# Altium schematic sheet CUBETTO-IO.SchDoc(CUBETTO-IO)
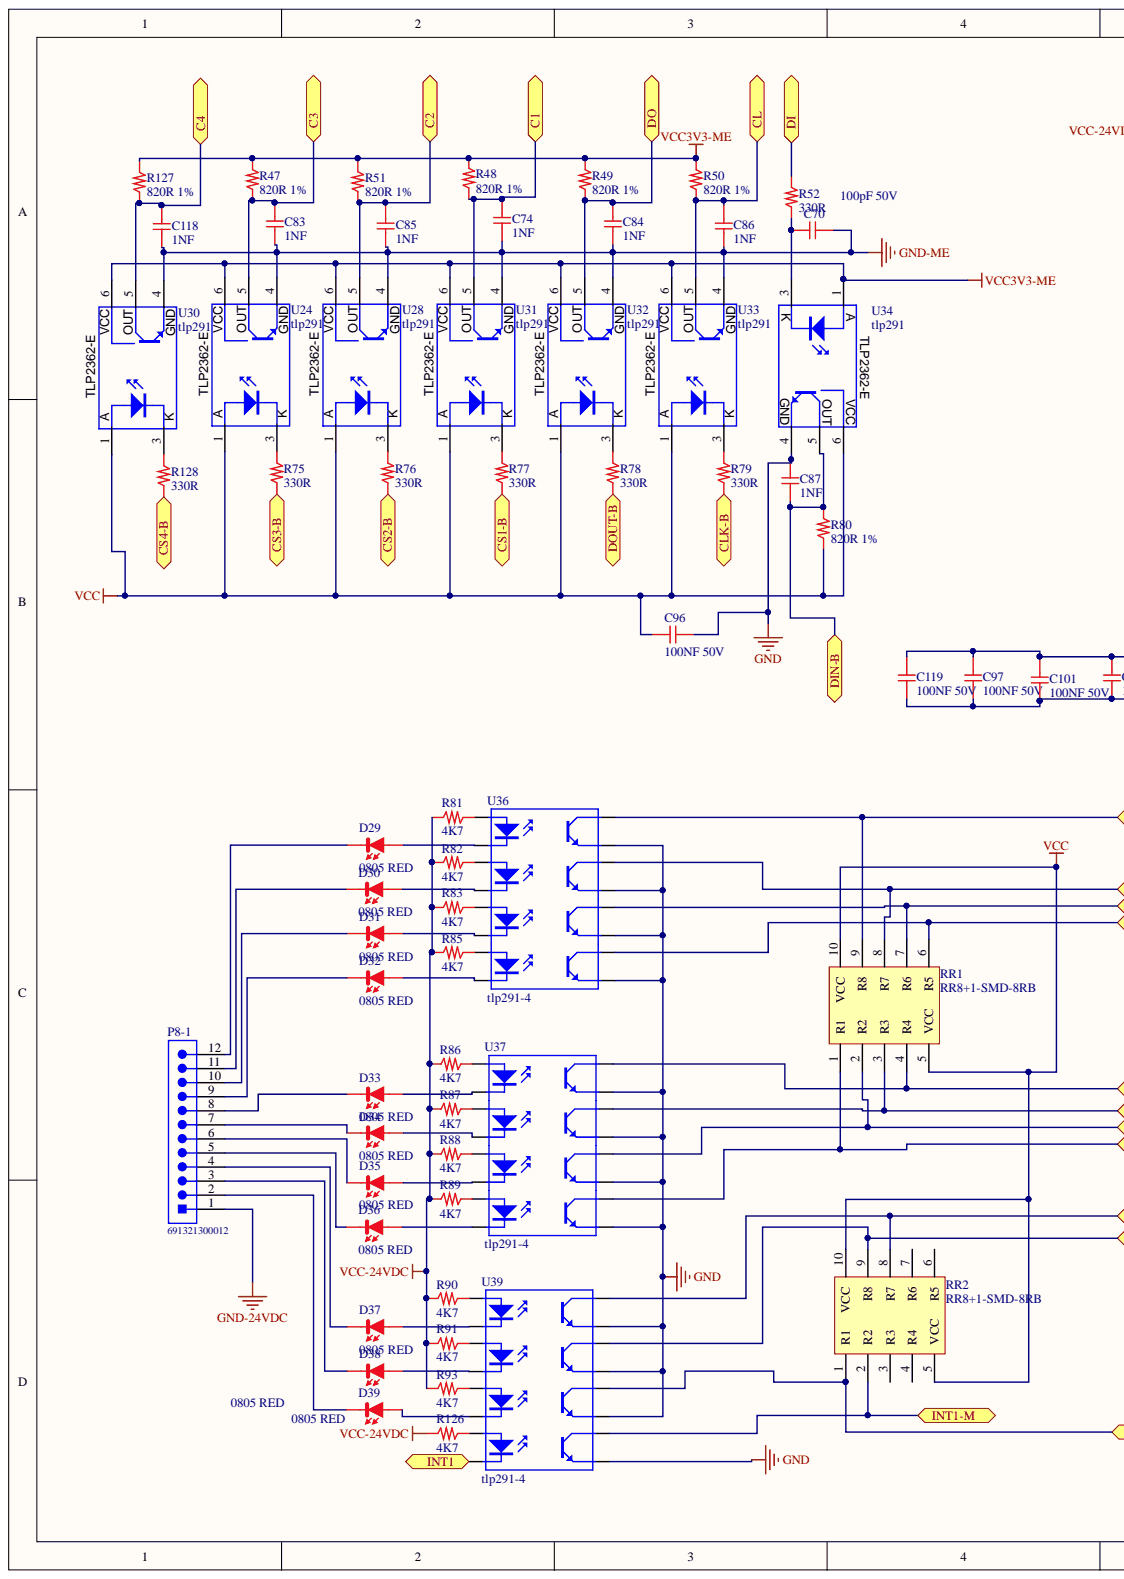
[diagram p0: CUBETTO-IO.SchDoc, left]
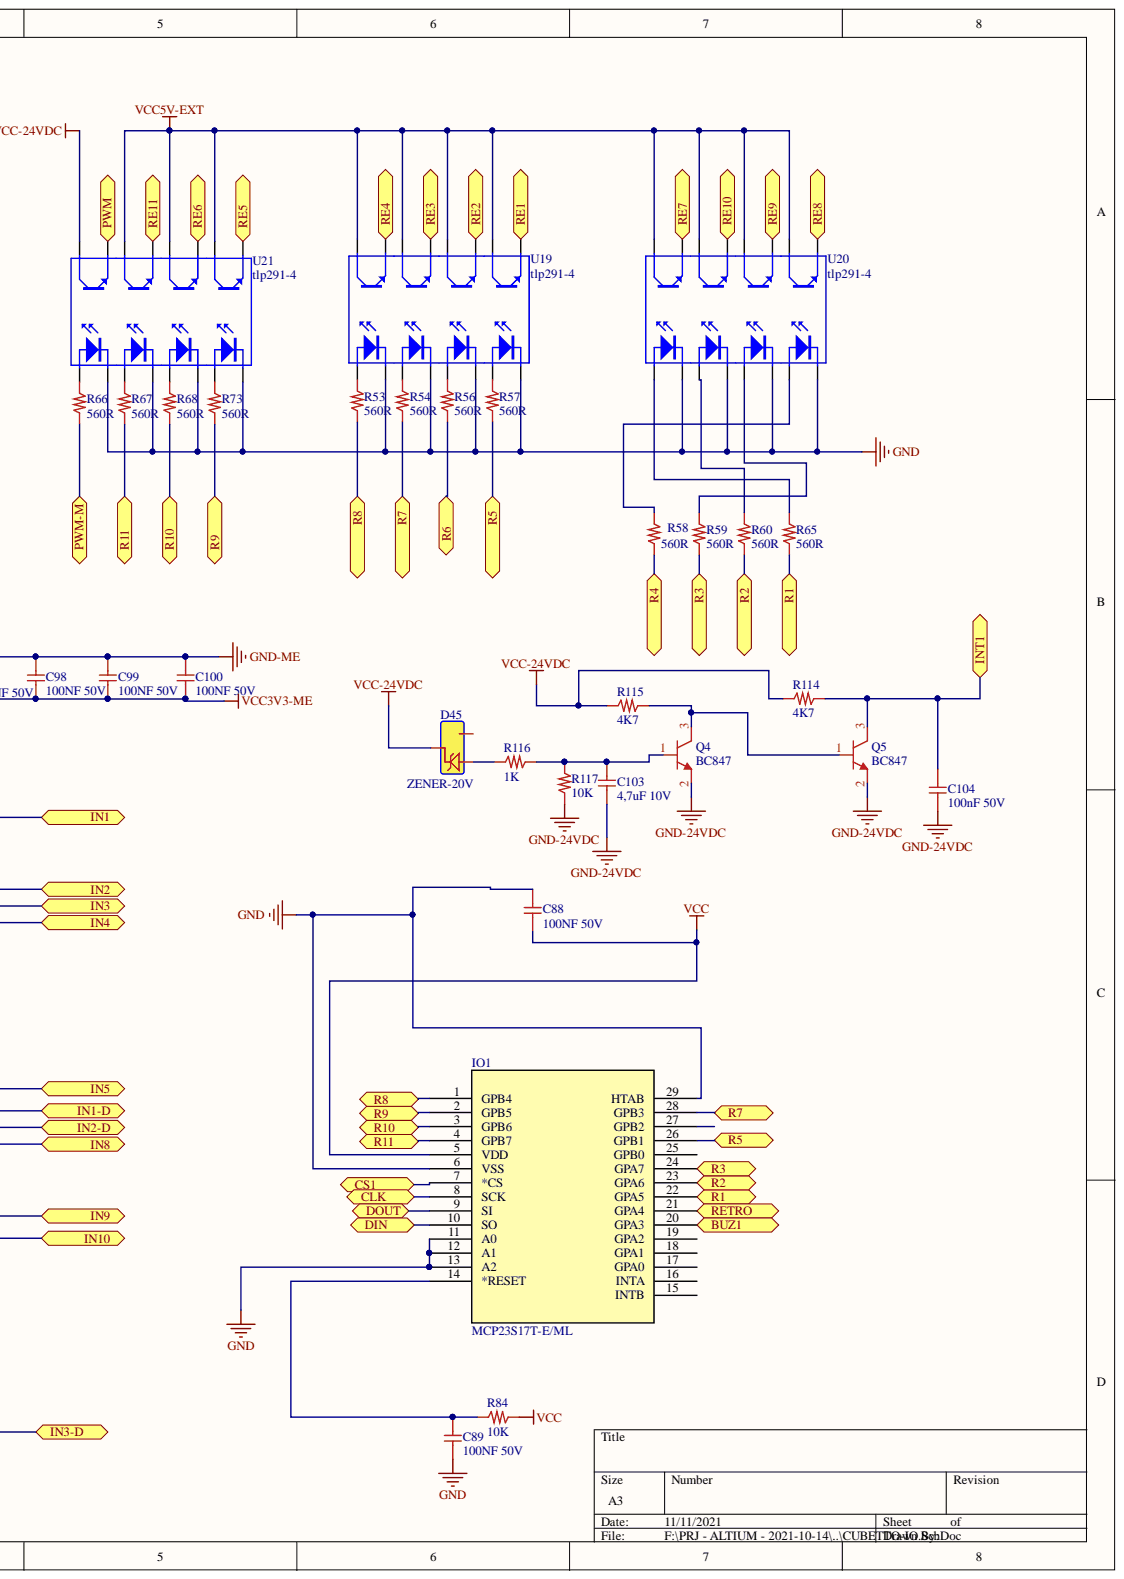
[diagram p1: CUBETTO-IO.SchDoc, right]

## Parts
C70  100pF 50V  [0402_C]
C74  1NF  [0402_C]
C83  1NF  [0402_C]
C84  1NF  [0402_C]
C85  1NF  [0402_C]
C86  1NF  [0402_C]
C87  1NF  [0402_C]
C88  100NF 50V  [0603_c]
C89  100NF 50V  [0603_c]
C96  100NF 50V  [0603_c]
C97  100NF 50V  [0603_c]
C98  100NF 50V  [0603_c]
C99  100NF 50V  [0603_c]
C100  100NF 50V  [0603_c]
C101  100NF 50V  [0603_c]
C103  4,7uF 10V  [0603_c]
C104  100nF 50V  [0603_c]
C118  1NF  [0402_C]
C119  100NF 50V  [0603_c]
D29  0805 RED  [0805_Red]
D30  0805 RED  [0805_Red]
D31  0805 RED  [0805_Red]
D32  0805 RED  [0805_Red]
D33  0805 RED  [0805_Red]
D34  0805 RED  [0805_Red]
D35  0805 RED  [0805_Red]
D36  0805 RED  [0805_Red]
D37  0805 RED  [0805_Red]
D38  0805 RED  [0805_Red]
D39  0805 RED  [0805_Red]
D45  ZENER-20V  [SOT23]
IO1  MCP23S17T-E/ML  [QFN28_6x6MC]
P8-1  691321300012  [691321300012]
Q4  BC847  [SOT23]
Q5  BC847  [SOT23]
R47  820R 1%  [0603_R]
R48  820R 1%  [0603_R]
R49  820R 1%  [0603_R]
R50  820R 1%  [0603_R]
R51  820R 1%  [0603_R]
R52  330R  [0603_R]
R53  560R  [0603_R]
R54  560R  [0603_R]
R56  560R  [0603_R]
R57  560R  [0603_R]
R58  560R  [0603_R]
R59  560R  [0603_R]
R60  560R  [0603_R]
R65  560R  [0603_R]
R66  560R  [0603_R]
R67  560R  [0603_R]
R68  560R  [0603_R]
R73  560R  [0603_R]
R75  330R  [0603_R]
R76  330R  [0603_R]
R77  330R  [0603_R]
R78  330R  [0603_R]
R79  330R  [0603_R]
R80  820R 1%  [0603_R]
R81  4K7  [1206_R]
R82  4K7  [1206_R]
R83  4K7  [1206_R]
R84  10K  [0603_R]
R85  4K7  [1206_R]
R86  4K7  [1206_R]
R87  4K7  [1206_R]
R88  4K7  [1206_R]
R89  4K7  [1206_R]
R90  4K7  [1206_R]
R91  4K7  [1206_R]
R93  4K7  [1206_R]
R114  4K7  [0603_R]
R115  4K7  [0603_R]
R116  1K  [0603_R]
R117  10K  [0603_R]
R126  4K7  [1206_R]
R127  820R 1%  [0603_R]
R128  330R  [0603_R]
RR1  RR8+1-SMD-8RB  [RR8+1-SMD]
RR2  RR8+1-SMD-8RB  [RR8+1-SMD]
U19  tlp291-4  [SO16_NO]
U20  tlp291-4  [SO16_NO]
U21  tlp291-4  [SO16_NO]
U24  tlp291  [TLP2362-E]
U28  tlp291  [TLP2362-E]
U30  tlp291  [TLP2362-E]
U31  tlp291  [TLP2362-E]
U32  tlp291  [TLP2362-E]
U33  tlp291  [TLP2362-E]
U34  tlp291  [TLP2362-E]
U36  tlp291-4  [SO16_NO]
U37  tlp291-4  [SO16_NO]
U39  tlp291-4  [SO16_NO]

## Ports
BUZ1, C1, C2, C3, C4, CL, CLK, CLK-B, CS1, CS1-B, CS2-B, CS3-B, CS4-B, DI, DIN, DIN-B, DO, DOUT, DOUT-B, IN1, IN1-D, IN2, IN2-D, IN3, IN3-D, IN4, IN5, IN8, IN9, IN10, INT1, INT1-M, PWM, PWM-M, R1, R2, R3, R4, R5, R6, R7, R8, R9, R10, R11, RE1, RE2, RE3, RE4, RE5, RE6, RE7, RE8, RE9, RE10, RE11, RETRO

## Nets
GND: C87.1 C88.2 C89.1 C96.1 IO1.6(VSS) IO1.11(A0) IO1.12(A1) IO1.13(A2) IO1.29(HTAB) U19.2 U19.4 U19.6 U19.8 U20.2 U20.4 U20.6 U20.8 U21.2 U21.4 U21.6 U21.8 U34.4 U36.9 U36.11 U36.13 U36.15 U37.9 U37.11 U37.13 U37.15 U39.9 U39.11 U39.13 U39.15
GND-24VDC: C103.2 C104.2 P8-1.1 Q4.2 Q5.2 R117.2
GND-ME: C70.2 C74.2 C83.2 C84.2 C85.2 C86.2 C97.2 C98.2 C99.2 C100.2 C101.2 C118.2 C119.2 U24.4 U28.4 U30.4 U31.4 U32.4 U33.4
VCC: C88.1 C96.2 IO1.5(VDD) R80.2 R84.2 RR1.5(VCC) RR1.10(VCC) RR2.5(VCC) RR2.10(VCC) U24.1(A) U28.1(A) U30.1(A) U31.1(A) U32.1(A) U33.1(A) U34.6
VCC3V3-ME: C97.1 C98.1 C99.1 C100.1 C101.1 C119.1 R47.1 R48.2 R49.1 R50.1 R51.1 R127.1 U24.6(VCC) U28.6(VCC) U30.6(VCC) U31.6(VCC) U32.6(VCC) U33.6(VCC) U34.1
VCC5V-EXT: U19.10 U19.12 U19.14 U19.16 U20.10 U20.12 U20.14 U20.16 U21.10 U21.12 U21.14
VCC-24VDC: D45.3 R81.1 R82.1 R83.1 R85.1 R86.1 R87.1 R88.1 R89.1 R90.1 R91.1 R93.1 R114.2 R115.2 R126.1 U21.16
BUZ1: IO1.20(GPA3) ; port BUZ1
C1: C74.1 R48.1 U31.5(OUT) ; port C1
C2: C85.1 R51.2 U28.5(OUT) ; port C2
C3: C83.1 R47.2 U24.5(OUT) ; port C3
C4: C118.1 R127.2 U30.5(OUT) ; port C4
CL: C86.1 R50.2 U33.5(OUT) ; port CL
CLK: IO1.8(SCK) ; port CLK
CLK-B: R79.2 ; port CLK-B
CS1: IO1.7(*CS) ; port CS1
CS1-B: R77.2 ; port CS1-B
CS2-B: R76.2 ; port CS2-B
CS3-B: R75.2 ; port CS3-B
CS4-B: R128.2 ; port CS4-B
DI: R52.1 ; port DI
DIN: IO1.10(SO) ; port DIN
DIN-B: C87.2 R80.1 U34.5 ; port DIN-B
DO: C84.1 R49.2 U32.5(OUT) ; port DO
DOUT: IO1.9(SI) ; port DOUT
DOUT-B: R78.2 ; port DOUT-B
IN1: RR1.9(R8) U36.16 ; port IN1
IN1-D: RR1.3(R3) U37.14 ; port IN1-D
IN2: RR1.8(R7) U36.14 ; port IN2
IN2-D: RR1.2(R2) U37.12 ; port IN2-D
IN3: RR1.7(R6) U36.12 ; port IN3
IN3-D: RR2.1(R1) U39.12 ; port IN3-D
IN4: RR1.6(R5) U36.10 ; port IN4
IN5: RR1.4(R4) U37.16 ; port IN5
IN8: RR1.1(R1) U37.10 ; port IN8
IN9: RR2.8(R7) U39.16 ; port IN9
IN10: RR2.9(R8) U39.14 ; port IN10
INT1: C104.1 Q5.3 R114.1 U39.8 ; port INT1
INT1-M: RR2.2(R2) U39.10 ; port INT1-M
PWM: U21.15 ; port PWM
PWM-M: R66.2 ; port PWM-M
R1: IO1.22(GPA5) R65.2 ; port R1
R2: IO1.23(GPA6) R60.2 ; port R2
R3: IO1.24(GPA7) R59.2 ; port R3
R4: R58.2 ; port R4
R5: IO1.26(GPB1) R57.2 ; port R5
R6: R56.2 ; port R6
R7: IO1.28(GPB3) R54.2 ; port R7
R8: IO1.1(GPB4) R53.2 ; port R8
R9: IO1.2(GPB5) R73.2 ; port R9
R10: IO1.3(GPB6) R68.2 ; port R10
R11: IO1.4(GPB7) R67.2 ; port R11
RE1: U19.9 ; port RE1
RE2: U19.11 ; port RE2
RE3: U19.13 ; port RE3
RE4: U19.15 ; port RE4
RE5: U21.9 ; port RE5
RE6: U21.11 ; port RE6
RE7: U20.15 ; port RE7
RE8: U20.9 ; port RE8
RE9: U20.11 ; port RE9
RE10: U20.13 ; port RE10
RE11: U21.13 ; port RE11
RETRO: IO1.21(GPA4) ; port RETRO
NetC70_1: C70.1 R52.2 U34.3
NetC89_2: C89.2 IO1.14(*RESET) R84.1
NetC103_1: C103.1 Q4.1 R116.1 R117.1
NetD29_A: D29.A U36.2
NetD29_K: D29.K P8-1.12
NetD30_A: D30.A U36.4
NetD30_K: D30.K P8-1.11
NetD31_A: D31.A U36.6
NetD31_K: D31.K P8-1.10
NetD32_A: D32.A U36.8
NetD32_K: D32.K P8-1.9
NetD33_A: D33.A U37.2
NetD33_K: D33.K P8-1.8
NetD34_A: D34.A U37.4
NetD34_K: D34.K P8-1.7
NetD35_A: D35.A U37.6
NetD35_K: D35.K P8-1.6
NetD36_A: D36.A U37.8
NetD36_K: D36.K P8-1.5
NetD37_A: D37.A U39.2
NetD37_K: D37.K P8-1.4
NetD38_A: D38.A U39.4
NetD38_K: D38.K P8-1.3
NetD39_A: D39.A U39.6
NetD39_K: D39.K P8-1.2
NetD45_1: D45.1 R116.2
NetQ4_3: Q4.3 Q5.1 R115.1
NetR53_1: R53.1 U19.1
NetR54_1: R54.1 U19.3
NetR56_1: R56.1 U19.5
NetR57_1: R57.1 U19.7
NetR58_1: R58.1 U20.7
NetR59_1: R59.1 U20.5
NetR60_1: R60.1 U20.3
NetR65_1: R65.1 U20.1
NetR66_1: R66.1 U21.1
NetR67_1: R67.1 U21.3
NetR68_1: R68.1 U21.5
NetR73_1: R73.1 U21.7
NetR75_1: R75.1 U24.3
NetR76_1: R76.1 U28.3
NetR77_1: R77.1 U31.3
NetR78_1: R78.1 U32.3
NetR79_1: R79.1 U33.3
NetR81_2: R81.2 U36.1
NetR82_2: R82.2 U36.3
NetR83_2: R83.2 U36.5
NetR85_2: R85.2 U36.7
NetR86_2: R86.2 U37.1
NetR87_2: R87.2 U37.3
NetR88_2: R88.2 U37.5
NetR89_2: R89.2 U37.7
NetR90_2: R90.2 U39.1
NetR91_2: R91.2 U39.3
NetR93_2: R93.2 U39.5
NetR126_2: R126.2 U39.7
NetR128_1: R128.1 U30.3

Not connected: D45 2; IO1 15(INTB) 16(INTA) 17(GPA0) 18(GPA1) 19(GPA2) 25(GPB0) 27(GPB2); RR2 3(R3) 4(R4) 6(R5) 7(R6)

## Library data of the parts
C70 = 100pF 50V: Cap COG 100pF 50V; Produttore: ??; Cod. Interno: COCS506565000101; Library Name: CapSMD0402.SchLib; Component Kind: Standard; Cod. Produttore: ??
C74 C83-C87 C118 = 1NF 50V: Cap X7R 1NF 50V; Produttore: ??; Cod. Interno: COCS556565000102; Library Name: CapSMD0402.SchLib; Component Kind: Standard; Cod. Produttore: ??
C88 C89 C96-C101 C103 C104 C119 = 100NF 50V: Cap X7R 100nF 50V Flex Terminal; Produttore: Samsung; Cod. Produttore: CL10B104KB8SFNC; Cod. Interno: COCS50665050104; Library Name: CapSMD0603.SchLib; Component Kind: Standard; Cod. DigiKey: 1276-1936-2-ND
D29-D39 = LED_ROSSO_0805: LED Rosso 0805; V: 2.0V; Produttore: WE; Luminosità: 150mcd; Cod. Produttore: 150080RS75000; Cod. Interno: LEDS 2AC080RS7500; Component Kind: Standard; Library Name: DiodiLED.SchLib
D45 = CMPZ5241B: DIODE ZENER 11V 300MW SOT23; Vz: 10.45V - 11.55V; Produttore: Central Semiconductor; Cod. Interno: ZENS 620CMPZ5241B; Component Kind: Standard; Cod. Produttore: CMPZ5241B; Library Name: DiodiZENER.SchLib
IO1 = MCP23S17T-E/ML: SPI-IO 16IO; Vendor: Microchip; SourceLibrary: Microchip_2019-08-23_9; Built_By: EMA_Jessica; Type: DEV; RefDes: RefDes; Manufacturer_Part_Number: MCP23S17T-E/ML; Component Kind: Standard; Library Name: HSW.SchLib; Copyright: Copyright (C) 2018 Accelerated Designs. All rights reserved
P8-1 = 691321300012: Serie 321 - 3.81mm Vertical PCB Header WR-TBL, 12 pin; Pin Number: 12; Gender: Male; Published Date: June 2018; Pitch: 3.81 mm; Part Number: 691321300012; Packaging: Box; Operating Temperature: -40 to 105 degC; Mounting Technology: THT; Library Name: Pluggable PCB System WR-TBL_3.81 mm.SchLib; ComponentLink2URL: http://katalog.we-online.de/em/datasheet/6913213000xx.pdf; ComponentLink2Description: Datasheet; ComponentLink1URL: http://www.we-online.de; ComponentLink1Description: Manufacturer; Component Kind: Standard; Publisher: Wurth Elektronik
Q4 Q5 = MMBT3904LT1G: NPN Si Digital Transistor; Vce: 40V; Vcb: 60V; Ptot: 300mW; Produttore: ON Semiconductor; Ic: 200mA; Ft: 300MHz; Cod. Interno: TRXS 110MMBT3904LT1G; Component Kind: Standard; Cod. Produttore: MMBT3904LT1G; Library Name: TransitorSMD.SCHLIB
R47-R51 R80 R127 = 820R 1%: Res Film Spesso 1/16W 820R 1%; Produttore: ??; Cod. Interno: RESS4166356B0681; Library Name: ResSMD0603.SchLib; Component Kind: Standard; Cod. Produttore: ??
R52 R75-R79 R128 = 330R: Res Film Spesso 1/16W 330R 5%; Cod. Interno: RESS4166556B0331; Library Name: ResSMD0603.SchLib; Component Kind: Standard
R53 R54 R56-R60 R65-R68 R73 = 560R: Res Film Spesso 1/16W 560R 5%; Cod. Interno: RESS 4166556B0561; Library Name: ResSMD0603.SchLib; Component Kind: Standard
R81-R83 R85-R91 R93 R126 = 4K7: 4K7 1206 1/4W; Library Name: ResSMD1206.SchLib; Component Kind: Standard; Case: 1206
R84 = 10K: Res Film Spesso 1/16W 10K 5%; Produttore: ??; Cod. Interno: RESS4166556B0103??; Library Name: ResSMD0603.SchLib; Component Kind: Standard; Cod. Produttore: ??
R114-R117 = 2K7: Res Film Spesso 1/16W 2K7 5%; Produttore: VISHAY; Cod. Produttore: CRCW06032K70JNEA; Cod. Interno: RESS4166556B0272; Library Name: ResSMD0603.SchLib; Component Kind: Standard
U19-U21 U36 U37 U39 = tlp291_4: 4-Pin Phototransistor Optocoupler; Published: 24-Mar-1999; PackageReference: DIP-4; LatestRevisionNote: Sch pins 3 & 4 Swapped.; LatestRevisionDate: 04-Mar-2005; DatasheetVersion: Nov-2003; Component Kind: Standard; Publisher: Altium Limited; Library Name: HSW.SchLib
U24 U28 U30-U34 = TLP2362-E: 4-Pin Phototransistor Optocoupler; Published: 24-Mar-1999; PackageReference: DIP-4; LatestRevisionNote: Sch pins 3 & 4 Swapped.; LatestRevisionDate: 04-Mar-2005; DatasheetVersion: Nov-2003; Component Kind: Standard; Publisher: Altium Limited; Library Name: HSW.SchLib
RR1 RR2 = RR8+1-SMD-8RB: Component Kind: Standard; Library Name: HSW.SchLib
